# Altium schematic sheet MCU.SchDoc
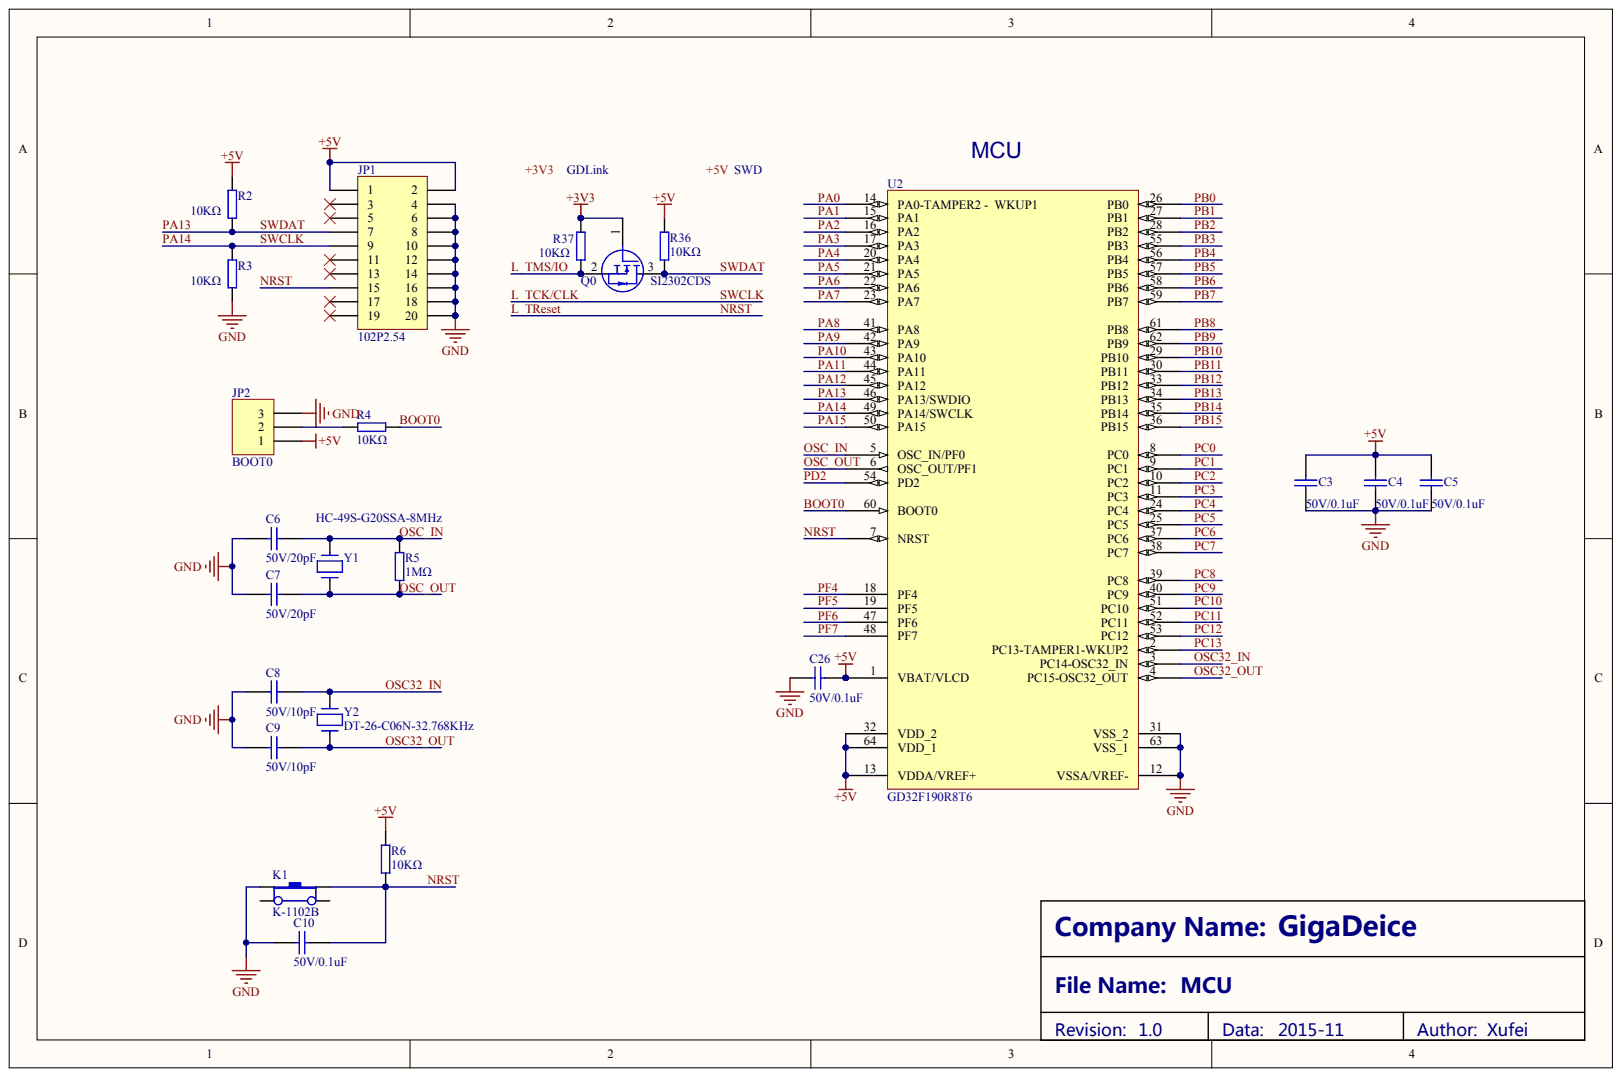
[diagram p0: MCU.SchDoc]

## Parts
C3  50V/0.1uF
C4  50V/0.1uF
C5  50V/0.1uF
C6  50V/20pF
C7  50V/20pF
C8  50V/10pF
C9  50V/10pF
C10  50V/0.1uF
C26  50V/0.1uF
JP1  10×2P2.54
JP2  BOOT0
K1  K-1102B
Q0  SI2302CDS
R2  10KΩ
R3  10KΩ
R4  10KΩ
R5  1MΩ
R6  10KΩ
R36  10KΩ
R37  10KΩ
U2  GD32F190R8T6
Y1  HC-49S-G20SSA-8MHz
Y2  DT-26-C06N-32.768KHz

## Nets
+3V3: Q0.1 R37.2
+5V: C3.2 C4.2 C5.2 C26.1 JP1.1 JP1.2 JP2.1 R2.2 R6.2 R36.1 U2.1(VBAT/VLCD) U2.13(VDDA/VREF+) U2.32(VDD_2) U2.64(VDD_1)
GND: C3.1 C4.1 C5.1 C6.1 C7.1 C8.1 C9.2 C10.2 C26.2 JP1.4 JP1.6 JP1.8 JP1.10 JP1.12 JP1.14 JP1.16 JP1.18 JP1.20 JP2.3 K1.1 R3.1 U2.12(VSSA/VREF-) U2.31(VSS_2) U2.63(VSS_1)
BOOT0: R4.1 U2.60(BOOT0)
L_TCK/CLK: JP1.9 R3.2 U2.49(PA14/SWCLK)
L_TMS/IO: Q0.2 R37.1
L_TRESET: C10.1 JP1.15 K1.3 R6.1 U2.7(NRST)
OSC32_IN: C8.2 U2.3(PC14-OSC32_IN) Y2.1
OSC32_OUT: C9.1 U2.4(PC15-OSC32_OUT) Y2.2
OSC_IN: C6.2 R5.2 U2.5(OSC_IN/PF0) Y1.2
OSC_OUT: C7.2 R5.1 U2.6(OSC_OUT/PF1) Y1.1
PA0: U2.14(PA0-TAMPER2_-)
PA1: U2.15(PA1)
PA2: U2.16(PA2)
PA3: U2.17(PA3)
PA4: U2.20(PA4)
PA5: U2.21(PA5)
PA6: U2.22(PA6)
PA7: U2.23(PA7)
PA8: U2.41(PA8)
PA9: U2.42(PA9)
PA10: U2.43(PA10)
PA11: U2.44(PA11)
PA12: U2.45(PA12)
PA13: JP1.7 Q0.3 R2.1 R36.2 U2.46(PA13/SWDIO)
PA15: U2.50(PA15)
PB0: U2.26(PB0)
PB1: U2.27(PB1)
PB2: U2.28(PB2)
PB3: U2.55(PB3)
PB4: U2.56(PB4)
PB5: U2.57(PB5)
PB6: U2.58(PB6)
PB7: U2.59(PB7)
PB8: U2.61(PB8)
PB9: U2.62(PB9)
PB10: U2.29(PB10)
PB11: U2.30(PB11)
PB12: U2.33(PB12)
PB13: U2.34(PB13)
PB14: U2.35(PB14)
PB15: U2.36(PB15)
PC0: U2.8(PC0)
PC1: U2.9(PC1)
PC2: U2.10(PC2)
PC3: U2.11(PC3)
PC4: U2.24(PC4)
PC5: U2.25(PC5)
PC6: U2.37(PC6)
PC7: U2.38(PC7)
PC8: U2.39(PC8)
PC9: U2.40(PC9)
PC10: U2.51(PC10)
PC11: U2.52(PC11)
PC12: U2.53(PC12)
PC13: U2.2(PC13-TAMPER1-WKUP2)
PD2: U2.54(PD2)
PF4: U2.18(PF4)
PF5: U2.19(PF5)
PF6: U2.47(PF6)
PF7: U2.48(PF7)
NetJP2_2: JP2.2 R4.2

Not connected: JP1 3 5 11 13 17 19; K1 2 4
